# Altium schematic sheet Rectification filter.SchDoc
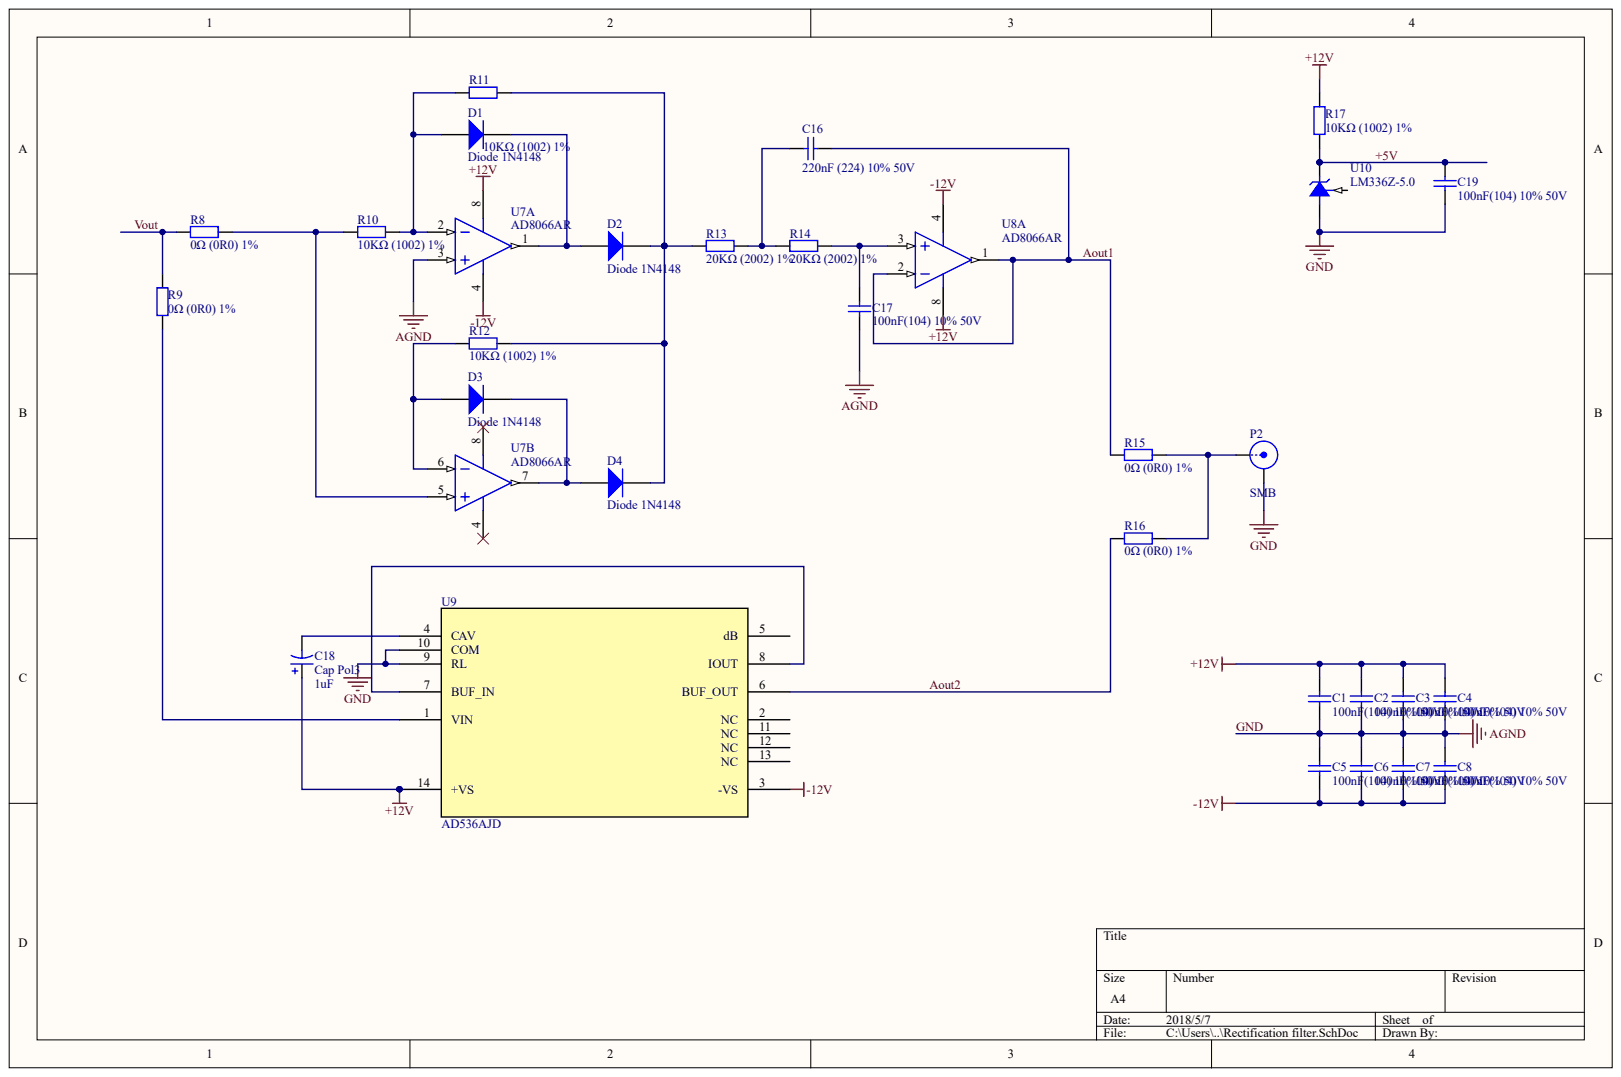
[diagram p0: Rectification filter.SchDoc]

## Parts
C1  100nF(104) 10% 50V
C2  100nF(104) 10% 50V
C3  100nF(104) 10% 50V
C4  100nF(104) 10% 50V
C5  100nF(104) 10% 50V
C6  100nF(104) 10% 50V
C7  100nF(104) 10% 50V
C8  100nF(104) 10% 50V
C16  220nF (224) 10% 50V
C17  100nF(104) 10% 50V
C18  Cap Pol3  value 1uF
C19  100nF(104) 10% 50V
D1  Diode 1N4148
D2  Diode 1N4148
D3  Diode 1N4148
D4  Diode 1N4148
P2  SMB
R8  0Ω (0R0) ±1%
R9  0Ω (0R0) ±1%  (value not drawn)
R10  10KΩ (1002) ±1%
R11  10KΩ (1002) ±1%
R12  10KΩ (1002) ±1%
R13  20KΩ (2002) ±1%
R14  20KΩ (2002) ±1%
R15  0Ω (0R0) ±1%
R16  0Ω (0R0) ±1%
R17  10KΩ (1002) ±1%
U7  AD8066AR  (parts A,B)
U8  AD8066AR  (part A)
U9  AD536AJD
U10  LM336Z-5.0

## Nets
+12V: C1.2 C2.2 C3.2 C4.2 C18.1 R17.2 U7.8 U8.8 U9.14(+VS)
-12V: C5.1 C6.1 C7.1 C8.1 U7.4 U8.4 U9.3(-VS)
+5V: C19.2 R17.1 U10.2
AOUT1: C16.2 R15.1 U8.1 U8.2
AOUT2: R16.1 U9.6(BUF_OUT)
GND: C1.1 C2.1 C3.1 C4.1 C5.2 C6.2 C7.2 C8.2 C17.1 C19.1 P2.2 U7.3 U9.9(RL) U9.10(COM) U10.3
VOUT: R8.1 R9.2
NetC16_1: C16.1 R13.2 R14.1
NetC17_2: C17.2 R14.2 U8.3
NetC18_2: C18.2 U9.4(CAV)
NetD1_1: D1.1 R10.2 R11.1 U7.2
NetD1_2: D1.2 D2.1 U7.1
NetD2_2: D2.2 D4.2 R11.2 R12.2 R13.1
NetD3_1: D3.1 R12.1 U7.6
NetD3_2: D3.2 D4.1 U7.7
NetP2_1: P2.1 R15.2 R16.2
NetR8_2: R8.2 R10.1 U7.5
NetR9_1: R9.1 U9.1(VIN)
NetU9_7: U9.7(BUF_IN) U9.8(IOUT)

Not connected: U9 2(NC) 5(dB) 11(NC) 12(NC) 13(NC); U10 1

## Library data of the parts
U7 U8 = (no symbol name): Generic Part Number: AD8066; Manufacturer: Analog Devices; Amplifiers Per Package: 2; Input Bias Current: 2pA; Input Offset Voltage: 400uV; Iq/Amp: 7.4mA; Slew Rate: 180V/us; Small Signal Bandwidth: 145MHz; V Span Max: 24V; V Span Min: 5V; DatasheetVersion: Rev. J; PackageVersion: 060506-A; PackageReference: R-8; PackageDescription: 8-Pin Standard Small Outline Package, Body 3.9 x 4.9 mm, Pitch 1.27 mm; Supplier 1: Digi-Key; Supplier Part Number 1: AD8066AR-ND; Mounting Technology: Surface Mount; Code_JEDEC: MS-012-AA; PartNumber: AD8066AR; ComponentLink1Description: Manufacturer URL; ComponentLink1URL: http://www.analog.com/en/index.html; ComponentLink2Description: Datasheet; ComponentLink2URL: http://www.analog.com/static/imported-files/data_sheets/AD8065_8066.pdf; ComponentLink3Description: Package Specification; ComponentLink3URL: http://www.analog.com/static/imported-files/packages/PKG_PDF/SOIC_Narrow%20(R)/R_8.pdf; ComponentLink4Description: Reference Circuit; ComponentLink4URL: http://www.analog.com/en/all-operational-amplifiers-op-amps/operational-amplifiers-op-amps/ad8066/products/product.html#product-recommendations
U10 = (no symbol name): Manufacturer: National Semiconductor; PackageTopMarking: NSXYTT*LM336*Z-5.0; PowerWise: N; CompType: Voltage Reference IC; Published: 4-Dec-2008; LatestRevisionDate: 15-Jul-2009; LatestRevisionNote: Sch pins 1 & 3 reversed.; DatasheetVersion: Jun-2005; PackageVersion: revG, Sep-2000; PackageReference: Z03A; PackageDescription: TO-92, Molded, 3 Lead; Supplier 1: Farnell; Supplier Part Number 1: 9488502; PartNumber: LM336Z-5.0; RoHS: N; PinCount: 3; Code_JEDEC: TO-226-AA; ComponentLink1Description: LM136-5.0 Datasheet; ComponentLink1URL: http://www.national.com/ds/LM/LM136-5.0.pdf; ComponentLink2Description: LM136-5.0 Web Page; ComponentLink2URL: http://www.national.com/pf/LM/LM136-5.0.html; ComponentLink3Description: Z03A Package Page; ComponentLink3URL: http://www.national.com/packaging/folders/z03a.html; Publisher: Altium Limited
C1-C8 C16-C19 D1-D4 P2 R8-R17 U9 = (no symbol name)
  C1-C8 C17 C19: ComponentLink1Description: 供应商链接; ComponentLink1URL: http://www.szlcsc.com/product/details_50686.html; Package: 0805; Supplier: LC; SuppliersPartNumber: C49678
  C16: ComponentLink1Description: 供应商链接; ComponentLink1URL: http://www.szlcsc.com/product/details_5810.html; Package: 0805; Supplier: LC; SuppliersPartNumber: C5378
  C18: Published: 8-Jun-2000; LatestRevisionDate: 29-May-2009; LatestRevisionNote: IPC-7351 Footprint Added.; PackageReference: C0805; PackageDescription: Chip Capacitor
  D1-D4: Published: 8-Jun-2000; LatestRevisionDate: 17-Jul-2002; LatestRevisionNote: Re-released for DXP Platform.; DatasheetVersion: 1997; PackageReference: DO-35; PackageDescription: Diode, Axial; Body 3.8 x 1.9 mm (LxDia. max), Lead Dia. 0.53 mm (max); Code_JEDEC: DO-204-AH; ComponentLink1Description: Manufacturer Link; ComponentLink1URL: http://www.fairchildsemi.com/; ComponentLink2Description: Datasheet; ComponentLink2URL: http://www.fairchildsemi.com/ds/1N%2F1N4148.pdf
  P2: LatestRevisionDate: 17-Jul-2002; LatestRevisionNote: Re-released for DXP Platform.
  R8 R9 R15 R16: ComponentLink1Description: 供应商链接; ComponentLink1URL: http://www.szlcsc.com/product/details_18165.html; Package: 0805; Supplier: LC; SuppliersPartNumber: C17477
  R10-R12 R17: ComponentLink1Description: 供应商链接; ComponentLink1URL: http://www.szlcsc.com/product/details_18102.html; Package: 0805; Supplier: LC; SuppliersPartNumber: C17414
  R13 R14: ComponentLink1Description: 供应商链接; ComponentLink1URL: http://www.szlcsc.com/product/details_4735.html; Package: 0805; Supplier: LC; SuppliersPartNumber: C4328
  U9: Manufacturer_Part_Number: AD536AJD; Vendor: Vendor; Value: Value; Type: Type; RefDes: Refdes
  C18 D1-D4 P2: Publisher: Altium Limited
